# Altium schematic sheet 05_WIFI_USB.SchDoc(05_WIFI_USB)
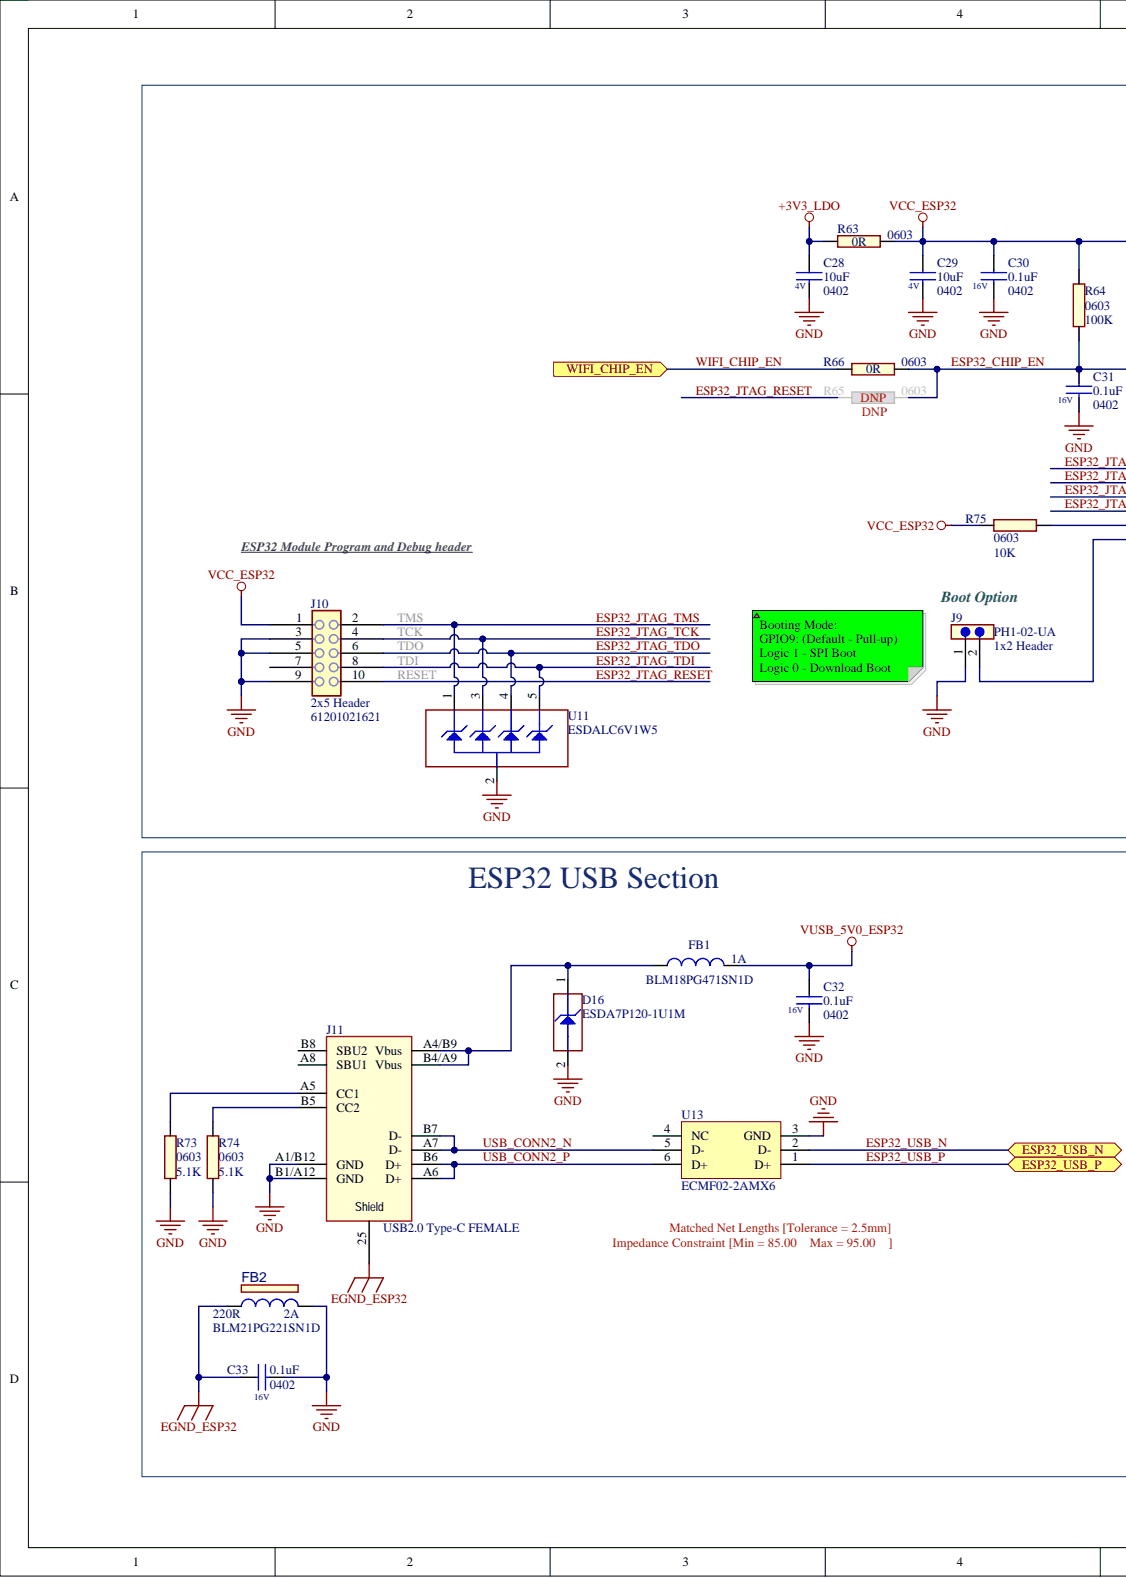
[diagram p0: 05_WIFI_USB.SchDoc, left]
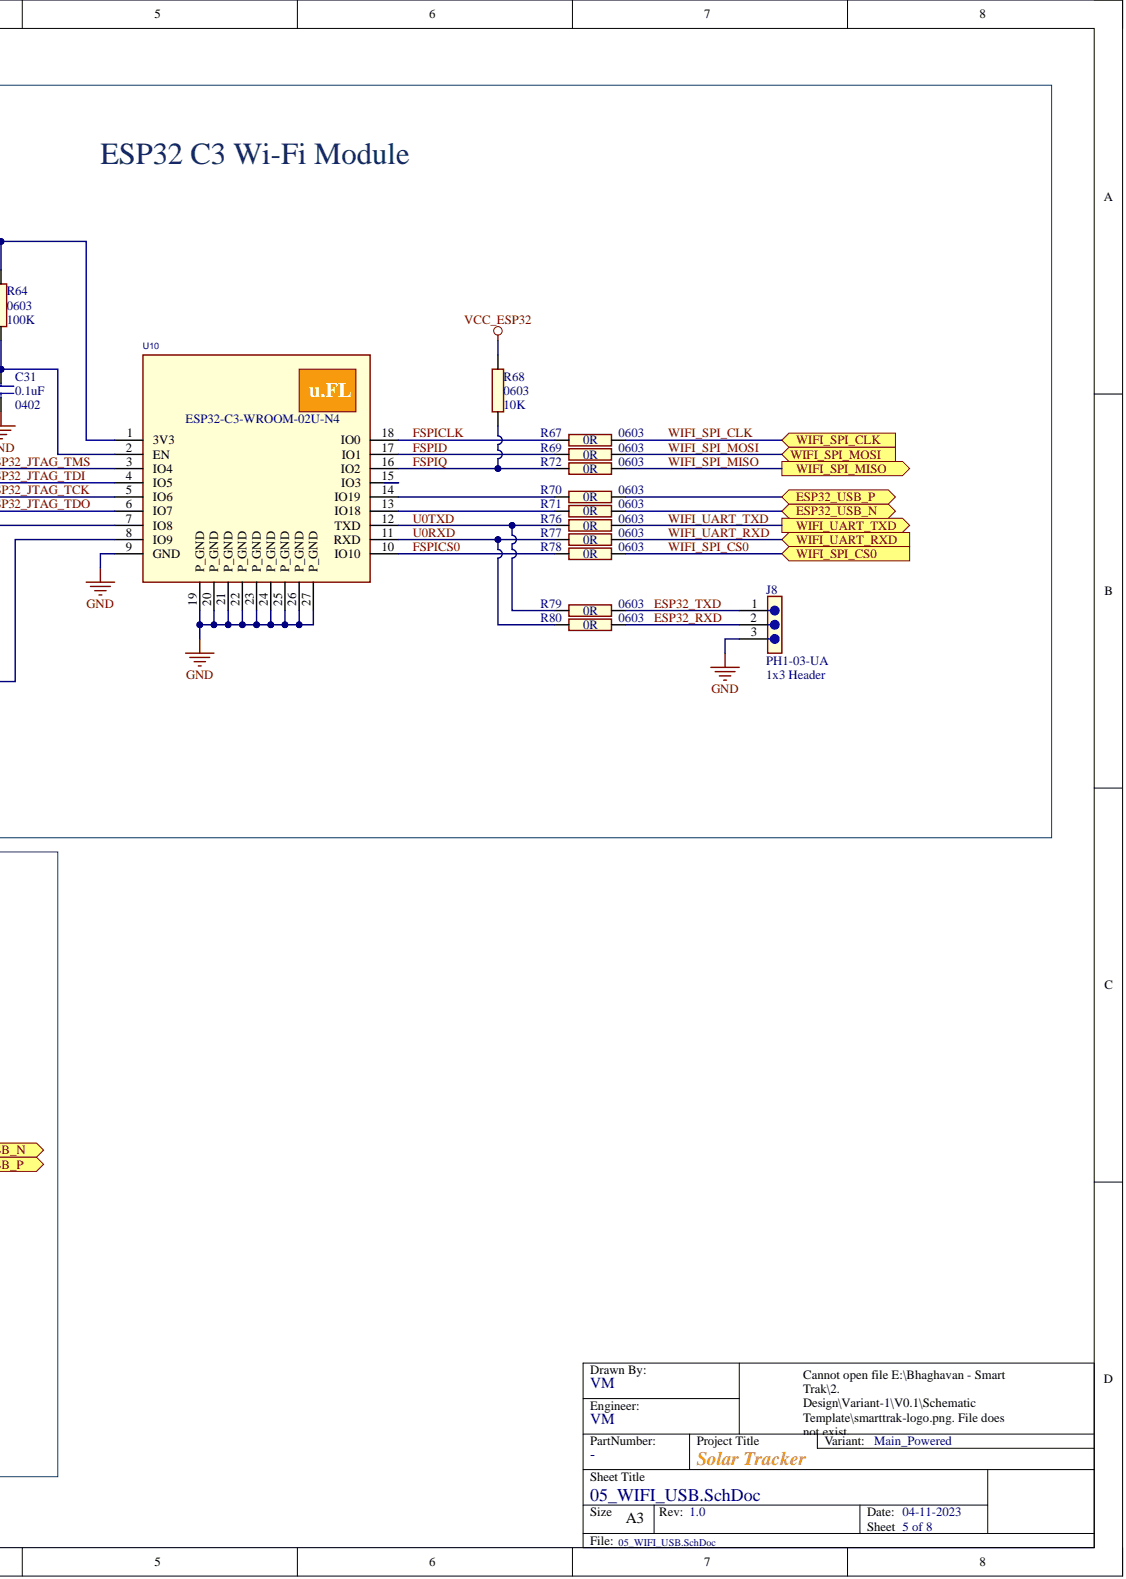
[diagram p1: 05_WIFI_USB.SchDoc, right]

## Parts
C28  value 10uF  Note: 0402  Voltage - Rated: 4V  [C0402]  (hidden comment: CAP_10uF_0402_4V)
C29  value 10uF  Note: 0402  Voltage - Rated: 4V  [C0402]  (hidden comment: CAP_10uF_0402_4V)
C30  value 0.1uF  Note: 0402  Voltage - Rated: 16V  [C0402]  (hidden comment: CAP_0.1uF_0402_16V)
C31  value 0.1uF  Note: 0402  Voltage - Rated: 16V  [C0402]  (hidden comment: CAP_0.1uF_0402_16V)
C32  value 0.1uF  Note: 0402  Voltage - Rated: 16V  [C0402]  (hidden comment: CAP_0.1uF_0402_16V)
C33  value 0.1uF  Note: 0402  Voltage - Rated: 16V  [C0402]  (hidden comment: CAP_0.1uF_0402_16V)
D16  value ESDA7P120-1U1M  MPN: ESDA7P120-1U1M  [DIODE_1610-2A]  (hidden comment: TVS_ESDA7P120-1U1M)
FB1  value BLM18PG471SN1D  Current Rating: 1A  MPN: BLM18PG471SN1D  [FB0603]  (hidden comment: FERRITE_BEAD_BLM18PG471SN1)
FB2  value 220R  Current Rating: 2A  MPN: BLM21PG221SN1D  [FB0805]  (hidden comment: Ferrite Bead)
J8  value PH1-03-UA  Manufacturer: PH1-03-UA  Note: 1x3 Header  [BERG_1X3]  (hidden comment: HEADER_1x3_2.54MM)
J9  value PH1-02-UA  Manufacturer: PH1-02-UA  Note: 1x2 Header  [BERG_1X2]  (hidden comment: HEADER_1x2_2.54MM)
J10  value 61201021621  MPN: 61201021621  Note: 2x5 Header  [WURTH_61201021621]  (hidden comment: JTAG_HEADER_2x5_TH)
J11  value USB2.0 Type-C FEMALE  [GCT_USB4105-GF-A]  (hidden comment: CON_USB-2.0_TYPE-C_RA)
R63  value 0R  Note: 0603  [R0603]  (hidden comment: RES_0R_0603)
R64  value 100K  Note: 0603  [R0603]  (hidden comment: RES_100K_0603)
R65  value 0R  Note: 0603  [R0603]  (hidden comment: RES_0R_0603)
R66  value 0R  Note: 0603  [R0603]  (hidden comment: RES_0R_0603)
R67  value 0R  Note: 0603  [R0603]  (hidden comment: RES_0R_0603)
R68  value 10K  Note: 0603  [R0603]  (hidden comment: RES_10K_0603)
R69  value 0R  Note: 0603  [R0603]  (hidden comment: RES_0R_0603)
R70  value 0R  Note: 0603  [R0603]  (hidden comment: RES_0R_0603)
R71  value 0R  Note: 0603  [R0603]  (hidden comment: RES_0R_0603)
R72  value 0R  Note: 0603  [R0603]  (hidden comment: RES_0R_0603)
R73  value 5.1K  Note: 0603  [R0603]  (hidden comment: RES_5.1K_0603)
R74  value 5.1K  Note: 0603  [R0603]  (hidden comment: RES_5.1K_0603)
R75  value 10K  Note: 0603  [R0603]  (hidden comment: RES_10K_0603)
R76  value 0R  Note: 0603  [R0603]  (hidden comment: RES_0R_0603)
R77  value 0R  Note: 0603  [R0603]  (hidden comment: RES_0R_0603)
R78  value 0R  Note: 0603  [R0603]  (hidden comment: RES_0R_0603)
R79  value 0R  Note: 0603  [R0603]  (hidden comment: RES_0R_0603)
R80  value 0R  Note: 0603  [R0603]  (hidden comment: RES_0R_0603)
U10  value ESP32-C3-WROOM-02U-N4  MPN: ESP32-C3-WROOM-02U-N4  [MODULE_ESP32-C3-WROOM-02-N4]  (hidden comment: ESP32_MODULE)
U11  value ESDALC6V1W5  MPN: ESDALC6V1W5  [SOT65P210X110-5N]  (hidden comment: TVS_ESDALC6V1W5)
U13  value ECMF02-2AMX6  MPN: ECMF02-2AMX6  [DFN50P170X150X60-6N]  (hidden comment: USB_CHOKE_ESD_ECMF02-2AMX6)

## Ports
ESP32_USB_N, ESP32_USB_P, WIFI_CHIP_EN, WIFI_SPI_CLK, WIFI_SPI_CS0, WIFI_SPI_MISO, WIFI_SPI_MOSI, WIFI_UART_RXD, WIFI_UART_TXD

## Nets
+3V3_LDO: C28.1 R63.1
EGND_ESP32: C33.1 FB2.1 J11.25
GND: C28.2 C29.2 C30.2 C31.2 C32.2 C33.2 D16.2 FB2.2 J8.3 J9.1 J10.3 J10.5 J10.9 J11.A1/B12(GND) J11.B1/A12(GND) R73.1 R74.1 U10.9(GND) U10.19(P_GND) U10.20(P_GND) U10.21(P_GND) U10.22(P_GND) U10.23(P_GND) U10.24(P_GND) U10.25(P_GND) U10.26(P_GND) U10.27(P_GND) U11.2 U13.3(GND)
VCC_ESP32: C29.1 C30.1 J10.1 R63.2 R64.2 R68.2 R75.2 U10.1(3V3)
VUSB_5V0_ESP32: C32.1 FB1.2
ESP32_CHIP_EN: C31.1 R64.1 R65.2 R66.2 U10.2(EN)
ESP32_JTAG_RESET: J10.10 R65.1
ESP32_JTAG_TCK: J10.4 U10.5(IO6) U11.3
ESP32_JTAG_TDI: J10.8 U10.4(IO5) U11.5
ESP32_JTAG_TDO: J10.6 U10.6(IO7) U11.4
ESP32_JTAG_TMS: J10.2 U10.3(IO4) U11.1
ESP32_RXD: J8.2 R80.2
ESP32_TXD: J8.1 R79.2
ESP32_USB_N: R71.2 U13.2(D-) ; port ESP32_USB_N
ESP32_USB_P: R70.2 U13.1(D+) ; port ESP32_USB_P
FSPICLK: R67.1 U10.18(IO0)
FSPICS0: R78.1 U10.10(IO10)
FSPID: R69.1 U10.17(IO1)
FSPIQ: R68.1 R72.1 U10.16(IO2)
U0RXD: R77.1 R80.1 U10.11(RXD)
U0TXD: R76.1 R79.1 U10.12(TXD)
USB_CONN2_N: J11.A7(D-) J11.B7(D-) U13.5(D-)
USB_CONN2_P: J11.A6(D+) J11.B6(D+) U13.6(D+)
WIFI_CHIP_EN: R66.1 ; port WIFI_CHIP_EN
WIFI_SPI_CLK: R67.2 ; port WIFI_SPI_CLK
WIFI_SPI_CS0: R78.2 ; port WIFI_SPI_CS0
WIFI_SPI_MISO: R72.2 ; port WIFI_SPI_MISO
WIFI_SPI_MOSI: R69.2 ; port WIFI_SPI_MOSI
WIFI_UART_RXD: R77.2 ; port WIFI_UART_RXD
WIFI_UART_TXD: R76.2 ; port WIFI_UART_TXD
NetD16_1: D16.1 FB1.1 J11.A4/B9(Vbus) J11.B4/A9(Vbus)
NetJ9_2: J9.2 U10.8(IO9)
NetJ11_A5: J11.A5(CC1) R73.2
NetJ11_B5: J11.B5(CC2) R74.2
NetR70_1: R70.1 U10.14(IO19)
NetR71_1: R71.1 U10.13(IO18)
NetR75_1: R75.1 U10.7(IO8)

Not connected: J10 7; J11 A8(SBU1) B8(SBU2); U10 15(IO3); U13 4(NC)

## Library data of the parts
C28 C29 = CAP_10uF_0402_4V: CAP CER 10UF 4V X5R 0402; Manufacturer: Yageo; MPN: CC0402MRX5R4BB106; Component Kind: Standard; Library Name: Project.SchLib
C30-C33 = CAP_0.1uF_0402_16V: CAP CER 0.1UF 16V X7R 0402; Manufacturer: Yageo; MPN: CC0402KRX7R7BB104; Component Kind: Standard; Library Name: Project.SchLib
D16 = TVS_ESDA7P120-1U1M: TVS DIODE 5.5VWM 11.5VC 1610; Manufacturer: STMICROELECTRONICS; Component Kind: Standard; Library Name: Project.SchLib; Note: -
FB1 = FERRITE_BEAD_BLM18PG471SN1: FERRITE BEAD 470 OHM 0603 1LN; Manufacturer: Murata Electronics; Component Kind: Standard; Library Name: Project.SchLib; Note: -
FB2 = FERRITE_BEAD_BLM21PG221SN1D: FERRITE BEAD 220 OHM 0805 1LN; Manufacturer: Murata; Component Kind: Standard; ComponentLink5Description: Open Footprint 2; ComponentLink6Description: Open Footprint 3; ComponentLink7Description: Open Footprint 4; Library Name: Project.SchLib; Note: BLM21PG221SN1D
J8 = HEADER_1x3_2.54MM: CONN HEADER VERT 3POS 2.54MM; MPN: Adam Tech; Component Kind: Standard; Library Name: Project.SchLib
J9 = HEADER_1x2_2.54MM: CONN HEADER VERT 2POS 2.54MM; MPN: Adam Tech; Component Kind: Standard; Library Name: Project.SchLib
J10 = JTAG_HEADER_2x5_TH: CONN HEADER VERT 10POS 2.54MM; Manufacturer: Würth Elektronik; Component Kind: Standard; Library Name: Project.SchLib
J11 = CON_USB-2.0_TYPE-C_RA: CONN RCP USB2.0 TYP C 24P SMD RA; Manufacturer: GCT; MPN: USB4105-GF-A; Component Kind: Standard; ComponentLink5Description: Open Footprint 2; ComponentLink6Description: Open Footprint 3; ComponentLink7Description: Open Footprint 4; Library Name: Project.SchLib; Note: USB4105-GF-A
R63 R65-R67 R69-R72 R76-R80 = RES_0R_0603: RES SMD 0 OHM JUMPER 1/10W 0603; Manufacturer: Yageo; MPN: RC0603JR-070RL; Component Kind: Standard; Library Name: Project.SchLib
R64 = RES_100K_0603: RES 100K OHM 5% 1/10W 0603; Manufacturer: Yageo; MPN: RC0603JR-07100KL; Component Kind: Standard; Library Name: Project.SchLib
R68 R75 = RES_10K_0603: RES 10K OHM 5% 1/10W 0603; Manufacturer: Yageo; MPN: RC0603JR-0710KL; Component Kind: Standard; Library Name: Project.SchLib
R73 R74 = RES_5.1K_0603: RES 5.1K OHM 5% 1/10W 0603; Manufacturer: Yageo; MPN: RC0603JR-075K1L; Component Kind: Standard; Library Name: Project.SchLib
U10 = ESP32_MODULE: RF TXRX MODULE WiFI Bluetooth U.FL SMD; Manufacturer: Espressif Systems; Component Kind: Standard; Library Name: Project.SchLib
U11 = TVS_ESDALC6V1W5: TVS DIODE 3VWM SOT323-5; Manufacturer: STMicroelectronics; Component Kind: Standard; ComponentLink1Description: Datasheet; ComponentLink1URL: http://tunmcdsvn.tun.st.com/Component_Datasheet_Library/Trunk/Diodes/STM_ESD_ESDALC6V1W5; Library Name: Project.SchLib
U13 = USB_CHOKE_ESD_ECMF02-2AMX6: CMC 200MA 2LN SMD ESD; Manufacturer: STMicroelectronics; Component Kind: Standard; Library Name: Project.SchLib
